# Altium schematic sheet MCU.SchDoc(MCU)
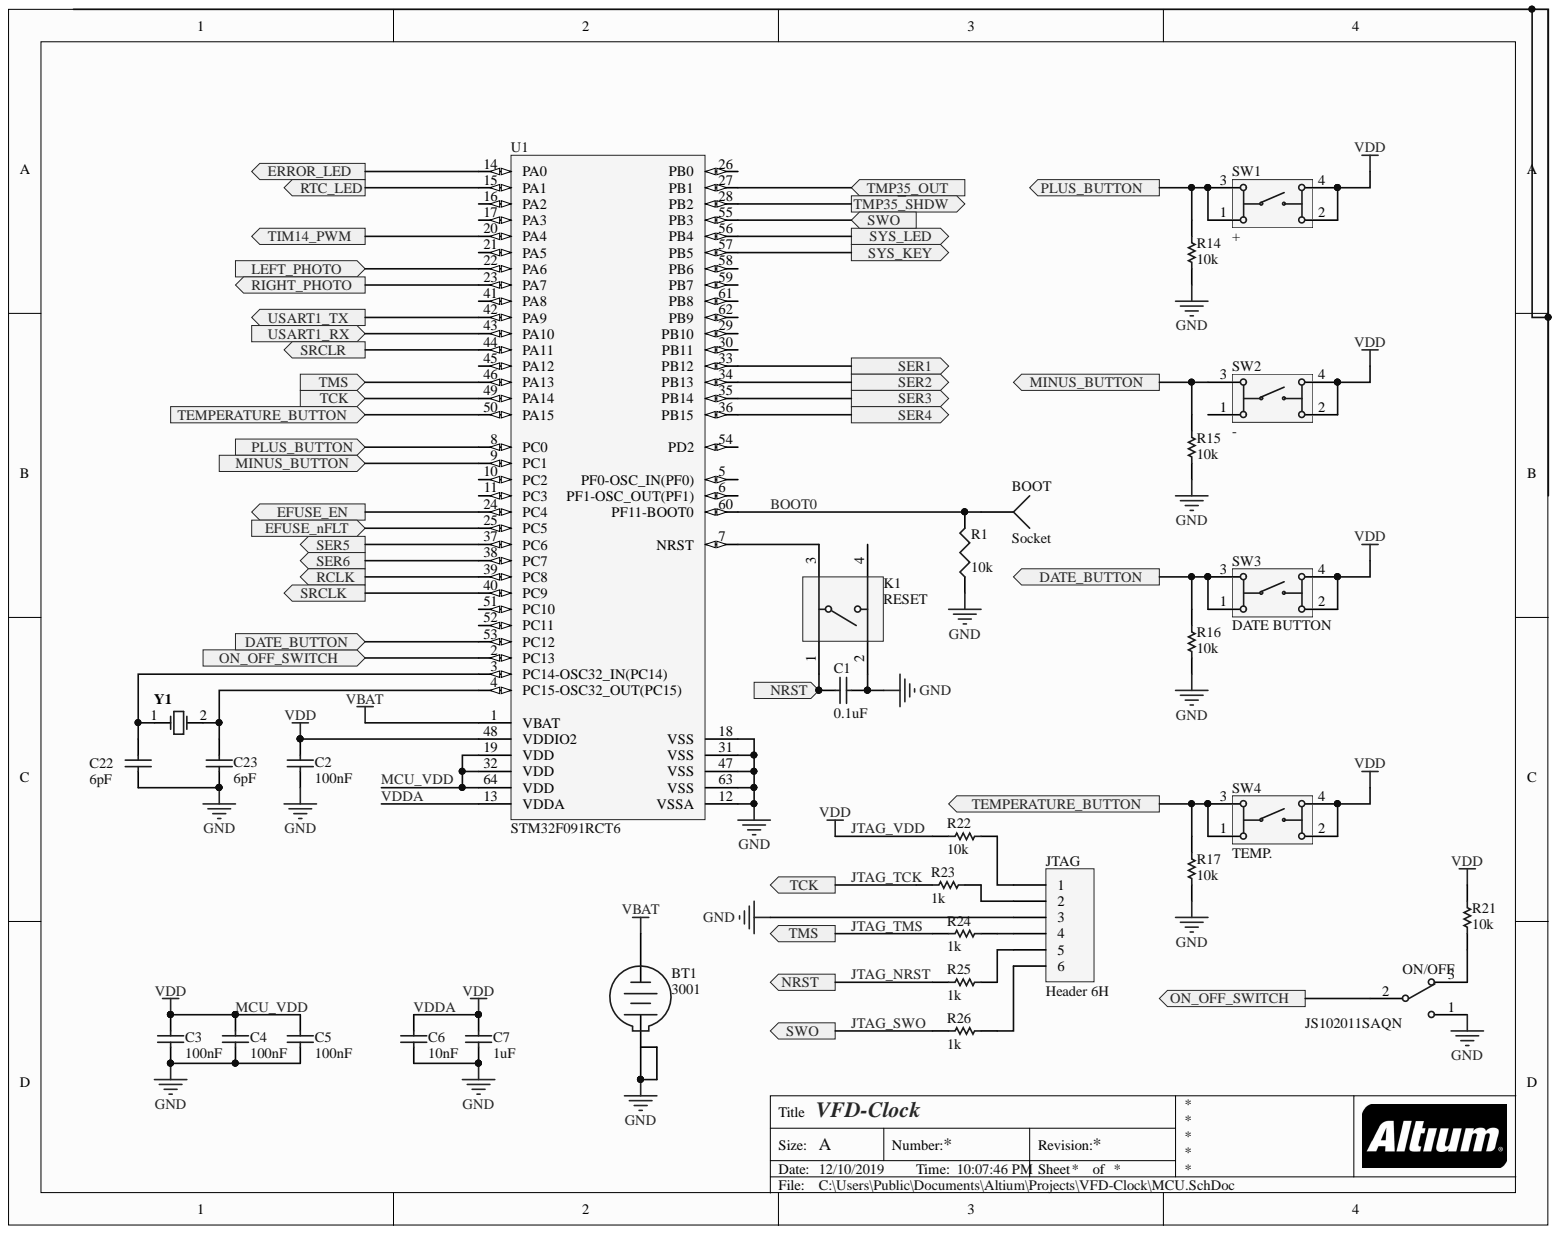
[diagram p0: MCU.SchDoc]

## Parts
BOOT  Socket  [PIN1]
BT1  3001  [KSTN-3001_V]
C1  0.1uF  [CAPC1608X90X40ML10T20]
C2  100nF  [CAPC1608X90X40ML10T20]
C3  100nF  [CAPC1608X90X40ML10T20]
C4  100nF  [CAPC1608X90X40ML10T20]
C5  100nF  [CAPC1608X90X40ML10T20]
C6  10nF  [CAPC1608X90X40ML10T20]
C7  1uF  [CAPC1608X90X20LL10]
C22  6pF  [CAPC1608X90X40ML10T20]
C23  6pF  [CAPC1608X90X40ML10T20]
JTAG  Header 6H  [HDR1X6H]
K1  RESET  [PNSC-EVPAA_V]
ON/OFF  JS102011SAQN  [CKCP-JS102011SAQN-3_V]
R1  value 10k  [J1-0603]
R14  10k  [RESC1608X55X30LL20T20]
R15  10k  [RESC1608X55X30LL20T20]
R16  10k  [RESC1608X55X30LL20T20]
R17  10k  [RESC1608X55X30LL20T20]
R21  10k  [RESC1608X55X30LL20T20]
R22  10k  [RESC1608X55X30LL20T20]
R23  1k  [RESC1608X55X30LL20T20]
R24  1k  [RESC1608X55X30LL20T20]
R25  1k  [RESC1608X55X30LL20T20]
R26  1k  [RESC1608X55X30LL20T20]
SW1  +  [434331045822]
SW2  -  [434331045822]
SW3  DATE BUTTON  [434331045822]
SW4  TEMP.  [434331045822]
U1  STM32F091RCT6  [STM-LQFP64_L]
Y1  ABS07-120-32.768KHZ-T  [ABRA-ABS07_A_V]  (value not drawn)

## Ports
DATE_BUTTON, EFUSE_EN, EFUSE_nFLT, ERROR_LED, LEFT_PHOTO, MINUS_BUTTON, NRST, ON_OFF_SWITCH, PLUS_BUTTON, RCLK, RIGHT_PHOTO, RTC_LED, SER1, SER2, SER3, SER4, SER5, SER6, SRCLK, SWO, SYS_KEY, SYS_LED, TCK, TEMPERATURE_BUTTON, TIM14_PWM, TMP35_OUT, TMP35~{_}SHDW, TMS, USART1_RX, USART1_TX, ~{SRCLR}

## Nets
GND: BT1.1 BT1.2 C1.2 C2.1 C3.1 C4.1 C5.1 C6.2 C7.1 C22.1 C23.1 JTAG.3 K1.2 ON/OFF.1 R1.1 R14.1 R15.1 R16.1 R17.1 U1.12(VSSA) U1.18(VSS) U1.31(VSS) U1.47(VSS) U1.63(VSS)
VBAT: BT1.3 U1.1(VBAT)
~{SRCLR}: U1.44(PA11) ; port ~{SRCLR}
BOOT0: BOOT.1 R1.2 U1.60(PF11-BOOT0)
DATE_BUTTON: R16.2 SW3.1 SW3.3 U1.53(PC12) ; port DATE_BUTTON
EFUSE_EN: U1.24(PC4) ; port EFUSE_EN
EFUSE_nFLT: U1.25(PC5) ; port EFUSE_nFLT
ERROR_LED: U1.14(PA0) ; port ERROR_LED
JTAG_NRST: C1.1 K1.1 R25.2 ; port NRST
JTAG_SWO: R26.2 U1.55(PB3) ; port SWO
JTAG_TCK: R23.2 U1.49(PA14) ; port TCK
JTAG_TMS: R24.2 U1.46(PA13) ; port TMS
JTAG_VDD: C2.2 C3.2 C4.2 C5.2 C6.1 C7.2 R21.2 R22.2 SW1.2 SW1.4 SW2.2 SW2.4 SW3.2 SW3.4 SW4.2 SW4.4 U1.13(VDDA) U1.19(VDD) U1.32(VDD) U1.48(VDDIO2) U1.64(VDD)
LEFT_PHOTO: U1.22(PA6) ; port LEFT_PHOTO
MINUS_BUTTON: R15.2 SW2.3 U1.9(PC1) ; port MINUS_BUTTON
ON_OFF_SWITCH: ON/OFF.2 U1.2(PC13) ; port ON_OFF_SWITCH
PLUS_BUTTON: R14.2 SW1.1 SW1.3 U1.8(PC0) ; port PLUS_BUTTON
RCLK: U1.39(PC8) ; port RCLK
RIGHT_PHOTO: U1.23(PA7) ; port RIGHT_PHOTO
RTC_LED: U1.15(PA1) ; port RTC_LED
SER1: U1.33(PB12) ; port SER1
SER2: U1.34(PB13) ; port SER2
SER3: U1.35(PB14) ; port SER3
SER4: U1.36(PB15) ; port SER4
SER5: U1.37(PC6) ; port SER5
SER6: U1.38(PC7) ; port SER6
SRCLK: U1.40(PC9) ; port SRCLK
SYS_KEY: U1.57(PB5) ; port SYS_KEY
SYS_LED: U1.56(PB4) ; port SYS_LED
TEMPERATURE_BUTTON: R17.2 SW4.1 SW4.3 U1.50(PA15) ; port TEMPERATURE_BUTTON
TIM14_PWM: U1.20(PA4) ; port TIM14_PWM
TMP35~{_}SHDW: U1.28(PB2) ; port TMP35~{_}SHDW
TMP35_OUT: U1.27(PB1) ; port TMP35_OUT
USART1_RX: U1.43(PA10) ; port USART1_RX
USART1_TX: U1.42(PA9) ; port USART1_TX
NetC22_2: C22.2 U1.3(PC14-OSC32_IN(PC14)) Y1.1
NetC23_2: C23.2 U1.4(PC15-OSC32_OUT(PC15)) Y1.2
NetJTAG_1: JTAG.1 R22.1
NetJTAG_2: JTAG.2 R23.1
NetJTAG_4: JTAG.4 R24.1
NetJTAG_5: JTAG.5 R25.1
NetJTAG_6: JTAG.6 R26.1
NetK1_3: K1.3 U1.7(NRST)
NetON/OFF_3: ON/OFF.3 R21.1
N00053:

Not connected: K1 4; SW2 1; U1 5(PF0-OSC_IN(PF0)) 6(PF1-OSC_OUT(PF1)) 10(PC2) 11(PC3) 16(PA2) 17(PA3) 21(PA5) 26(PB0) 29(PB10) 30(PB11) 41(PA8) 45(PA12) 51(PC10) 52(PC11) 54(PD2) 58(PB6) 59(PB7) 61(PB8) 62(PB9)

## Library data of the parts
BT1 = CMP-2000-05463-1: Holder Battery Coin, 12 mm, 2-Pin THD, RoHS; Manufacturer: Keystone; Package Reference: 3001; Package Description: 2-Pin Through Hole Device, Body 13.21 x 12.07 mm; Mounting Technology: ThroughHole; Manufacturer URL: http://www.keyelco.com/; Library Name: Altium Content Vault; Datasheet Version: Rev. H, 09/2006; Datasheet URL: https://datasheet.ciiva.com/3/3001-3954.pdf; Component Kind: Standard
C1-C5 C22 C23 = CMP-2000-05002-1: Ceramic Multilayer Capacitor, 100 nF, +/- 10%, 50 V, -55 to 155 degC, 0603 (1608 Metric), RoHS, Tape and Reel; Manufacturer: Yageo; Voltage - Rated: 16V; Temperature Coefficient: X7R; Product Training Modules: MultilayerCeramicCapacitors; Other Names: 311-1088-6; Online Catalog: CCSeries; Featured Product: CommodityMultilayerCeramicCapacitors–CCSeries; Capacitance: 0.1µF; Tolerance: ±10%; Thickness (Max): 0.035"(0.90mm); Standard Package: 1; Size / Dimension: 0.063"Lx0.031"W(1.60mmx0.80mm); Series: CC; Ratings: -; Packaging: Digi-Reel; Package Reference: 603; Package Description: 2-Pin Surface Mount Device, Body 1.6 x 0.8 mm; Package / Case: 0603(1608Metric); Operating Temperature: -55degC~125degC; Mounting Type: SurfaceMount,MLCC; Manufacturer URL: http://www.yageo.com/; Library Name: Altium Content Vault; Lead Style: -; Lead Spacing: -; Height - Seated (Max): -; Features: -; Family: CeramicCapacitors; Datasheet Version: Rev. 2, 05/2009; Datasheet URL: https://datasheet.ciiva.com/11786/0900766b80d84f1d-11786077.pdf; Component Kind: Standard; Category: Capacitors; Applications: GeneralPurpose
C6 = CMP-2100-03600-1: Chip Capacitor, 10 nF, +/- 10%, 50 V, -55 to 125 degC, 0603 (1608 Metric), RoHS, Tape and Reel; Manufacturer: Yageo; Voltage Rating (DC): 50V; Material: Ceramic; Insulation Resistance: 100GR; Dielectric: X7R; Width: 0.031inch; Voltage Rating: 50V; Voltage: 50V; Tolerance: 10%; Thickness: 0.035inch; Termination: SMD/SMT; RoHSCompliant: True; REACH SVHC: NoSVHC; Radiation Hardening: No; Packaging: TapeandReel; Package Reference: 0603; Package Quantity: 1; Package Description: 2-Pin Surface Mount Device, Body 1.6 x 0.8 mm; Number of Pins: 2; Mount: SurfaceMount; Min Operating Temperature: -55degC; Max Operating Temperature: 125degC; Manufacturer URL: http://www.yageo.com/; Library Name: Altium Content Vault; Length: 1.6mm; Lead Free: LeadFree; Height: 0.8mm; Depth: 0.8mm; Datasheet Version: Rev. 15, 10/2016; Datasheet URL: http://www.yageo.com/documents/recent/UPY-GPHC_X7R_6.3V-to-50V_15.pdf; Composition: Ceramic; Component Kind: Standard; Case Code (Metric): 1608; Case Code (Imperial): 0603; Case/Package: 0603; Capacitance: 10nF
C7 = CMP-2000-06025-1: Chip Capacitor, 1 uF, +/- 10%, 50 V, -55 to 85 degC, 0603 (1608 Metric), RoHS, Tape and Reel; PCN Part Number: MLCCPartNumberChange30/Nov/2012; Manufacturer: TDK; Voltage - Rated: 50V; Temperature Coefficient: X5R; Product Training Modules: CSeriesGeneralApplicationsSEAT,CCV,andTVCLDesignToolsGuidetoReplacinganElectrolyticCapacitorwithanMLCC; Other Names: 445-7468-6; Online Catalog: CSeries,GeneralPurpose; Design Resources: 20WNon-IsolatedBuckLEDDriverUsingPLLNK460KG; Capacitance: 1µF; Tolerance: ±10%; Thickness (Max): 0.035"(0.90mm); Standard Package: 1; Size / Dimension: 0.063"Lx0.031"W(1.60mmx0.80mm); Series: C; RoHS Information: RoHSCertificate-MLCC; Ratings: -; Packaging: Digi-Reel®; Package Reference: 0603; Package Description: 2-Pin Surface Mount Device, Body 1.6 x 0.8 mm; Package / Case: 0603(1608Metric); Operating Temperature: -55°C~85°C; Mounting Type: SurfaceMount,MLCC; Mounting Technology: SurfaceMount; Manufacturer URL: http://www.tdk.com/; Library Name: Altium Content Vault; Lead Style: -; Lead Spacing: -; Height - Seated (Max): -; Features: -; Family: CeramicCapacitors; Datasheet Version: 08/2016; Datasheet URL: https://product.tdk.com/info/en/catalog/datasheets/mlcc_commercial_general_en.pdf; Component Kind: Standard; Category: Capacitors; Applications: GeneralPurpose
JTAG = Header 6H: Header, 6-Pin, Right Angle; Signal Integrity: Connector; Library Name: Miscellaneous Connectors.IntLib; LatestRevisionNote: Re-released for DXP Platform.; LatestRevisionDate: 17-Jul-2002; Component Kind: Standard; Publisher: Altium Limited
K1 = CMP-2000-06231-1: Light Touch Switch, 100 mOhm, -40 to 85 degC, 4-Pin SMD, RoHS, Tape and Reel; Part No: EVP-AA002K; Manufacturer: Panasonic; Switch Configuration: N.O.; Pole Throw Configuration: SPST; Operating Force: 1N; Maximum DC Voltage Rating: 15V; Illumination Type: N/R; Ground Terminal: No; Electrical Life: 1000000Cycles; Dielectric Strength: 250VAC; COO: JP; Contact Rating @ Voltage: 0.02@15VDCA; Body Orientation: Straight; Actuator Style: RectangularButton; Actuator Length: 0.3mm; Actuator Color: Black; Type: LightTouch; Supplier Standard Pack: 5,000; Supplier: PanasonicIndustrialDevices; Seal Location: N/A; Seal Description: N/A; RoHS: Yes; Product Weight: N/A; Packaging: EmbossedTapeandReel; Package Reference: EVPAA; Package Description: 4-Pin Surface Mount Device, Body 2.9 x 3.5 mm, Pitch 1.45 mm; Operating Temperature: -40to85C; Mounting Technology: SurfaceMount; Mounting: SurfaceMount,J-Bend; Minimum Insulation Resistance: 100MOhm; Mechanical Life: N/A; Maximum Contact Resistance: 100mOhm; Maximum AC Voltage Rating: N/A; Manufacturer URL: http://www.panasonic.com/; HTS: 8507204000; Housing Material: N/A; Family: EVPAA; ECCN: EAR99; Dimension: 4.3x2.9x1.7mm; Datasheet Version: 04/2016; Datasheet URL: https://industrial.panasonic.com/cdbs/www-data/pdf/ATV0000/ATV0000C1.pdf; Contact Plating: N/A; Contact Material: N/A; Component Kind: Standard; Base Material: N/A; Library Name: VFD_Clock_lib.IntLib
ON/OFF = CMP-2000-06501-1: Sub-Miniature Slide Switch, SPDT, -40 to 85 degC, 3-Pin SMD, RoHS, Tape and Reel; Manufacturer: C&K Components; Minimum Insulation Resistance: 100MOhm; Maximum DC Voltage Rating: 6V; Maximum Contact Resistance: 70mOhm; Electrical Life: 15000Cycles; Dielectric Strength: 500VAC; COO: US; Contact Rating @ Voltage: 0.3@6VDCA; Body Orientation: RightAngle; Actuator Style: SideSlide; Actuator Material: Nylon4/6; Actuator Color: Black; Type: Sub-Miniature; Switch Configuration: N/A; Supplier: C&KComponents; Seal Location: N/A; Seal Description: N/A; RoHS: Yes; Product Weight: N/A; Pole Throw Configuration: SPDT; Part No: JS102011SAQN; Packaging: TapeandReel; Package Reference: SMT; Package Description: 3-Pin Surface Mount Device, Body 9 x 3.6 mm, Height 3.5 mm; Operating Temperature: -40to85C; Mounting Technology: SurfaceMount; Mounting: SurfaceMount,GullWing; Mechanical Life: N/A; Maximum AC Voltage Rating: N/A; Manufacturer URL: http://www.ckswitches.com/; Library Name: Altium Content Vault; HTS: 8536509050; Housing Material: Nylon4/6; Family: JS; ECCN: EAR99; Dimension: 9x4.3mm; Datasheet Version: 12/2014; Datasheet URL: https://datasheet.ciiva.com/1532/js-9dec14-1532400.pdf; Contact Plating: Silver; Contact Material: CopperAlloy; Component Kind: Standard; Base Material: N/A
R1 = Res3: Resistor; Simulation: RESISTOR; Signal Integrity: Res; Published: 8-Jun-2000; PackageReference: J1-0603; PackageDescription: Chip Resistor; Library Name: Miscellaneous Devices.IntLib; LatestRevisionNote: IPC-7351 Footprint Added.; LatestRevisionDate: 29-May-2009; Component Kind: Standard; Publisher: Altium Limited
R14-R17 R21-R26 = CMP-2000-00873-1: Part Number: ERA3AEB102V; Manufacturer: Panasonic; Library Name: Altium Content Vault; Component Kind: Standard
SW1-SW4 = CMP-1461-00001-1: WS-TASU SMD Tact Switch 4.7X3.5 mm side push; Manufacturer: Wuerth Elektronik; NoPins: 04; PartNumber: 434331045822; PackageReference: 434331045822; Library Name: Altium Content Vault; ComponentLink2URL: http://katalog.we-online.de/em/datasheet/434331045822.pdf; ComponentLink2Description: Datasheet; ComponentLink1URL: http://www.we-online.com; ComponentLink1Description: Manufacturer URL; Component Kind: Standard
U1 = CMP-0304-00199-2: ARM Cortex-M0 32-bit MCU, 256 KB Flash, 32 KB Internal RAM, 52 I/Os, 64-Pin LQFP, -40 to 85 degC, Tray; Manufacturer: STMicroelectronics; Supply Voltage(Vcc) min (V): 2; Operating Frequency(F) (Processor speed) (MHz): 48; Internal RAM Size (kB): 32; I/Os (High Current): 52; FLASH Size (Prog) (kB): 256; D/A Converter: 1; PartNumber: STM32F091RCT6; Packing: Tray; Package Reference: LQFP64; Package Description: 64-Pin Low-profile Quad Flat Package, Body 10 x 10 mm, Pitch 0.5 mm; Mounting Technology: Surface Mount; Library Name: Altium Content Vault; DatasheetVersion: Rev. 2; Core: ARM Cortex-M0; ComponentLink2URL: http://www.st.com/st-web-ui/static/active/en/resource/technical/document/datasheet/DM00115237.pdf; ComponentLink2Description: Datasheet; ComponentLink1URL: http://www.st.com/; ComponentLink1Description: Manufacturer URL; Component Kind: Standard
Y1 = CMP-2000-07587-1: Tuning Fork Crystal, 32.768 KHz, +/- 20 ppm, -40 to 85 degC, 2-Pin SMD, RoHS, Tape and Reel; Manufacturer: Abracon; Tolerance: 20ppm; Series Resistance: 60KOhm; Load Capacitance: 6pF; ESR (Equivalent Series Resistance): 55KOhm; Case\/Package: SMD/SMT; Width: 0.061inch; Termination: SMD/SMT; RoHSCompliant: True; REACH SVHC: NoSVHC; Radiation Hardening: No; Packaging: TapeandReel; Package Reference: ABS07; Package Quantity: 3000; Package Description: 2-Pin Surface Mount Device, Body 3.2 x 1.5 mm; Number of Pins: 2; Mounting Technology: SurfaceMount; Mount: SurfaceMount; Min Operating Temperature: -40degC; Max Operating Temperature: 85degC; Manufacturer URL: http://www.abracon.com/; Library Name: Altium Content Vault; Length: 3.2mm; Lead Free: LeadFree; Height: 0.035inch; Frequency Tolerance: 20ppm; Frequency: 32.768KHz; Depth: 1.5mm; Datasheet Version: 11/2014; Datasheet URL: http://www.abracon.com/Resonators/ABS07-120-32.768kHz-T.pdf; Component Kind: Standard
BOOT = Socket: Signal Integrity: Connector; Library Name: Miscellaneous Connectors.IntLib; LatestRevisionNote: Re-released for DXP Platform.; LatestRevisionDate: 17-Jul-2002; Description: Socket; Component Kind: Standard; Publisher: Altium Limited
